# Altium schematic sheet 04_RTC_EEPROM.SchDoc(04_RTC_EEPROM)
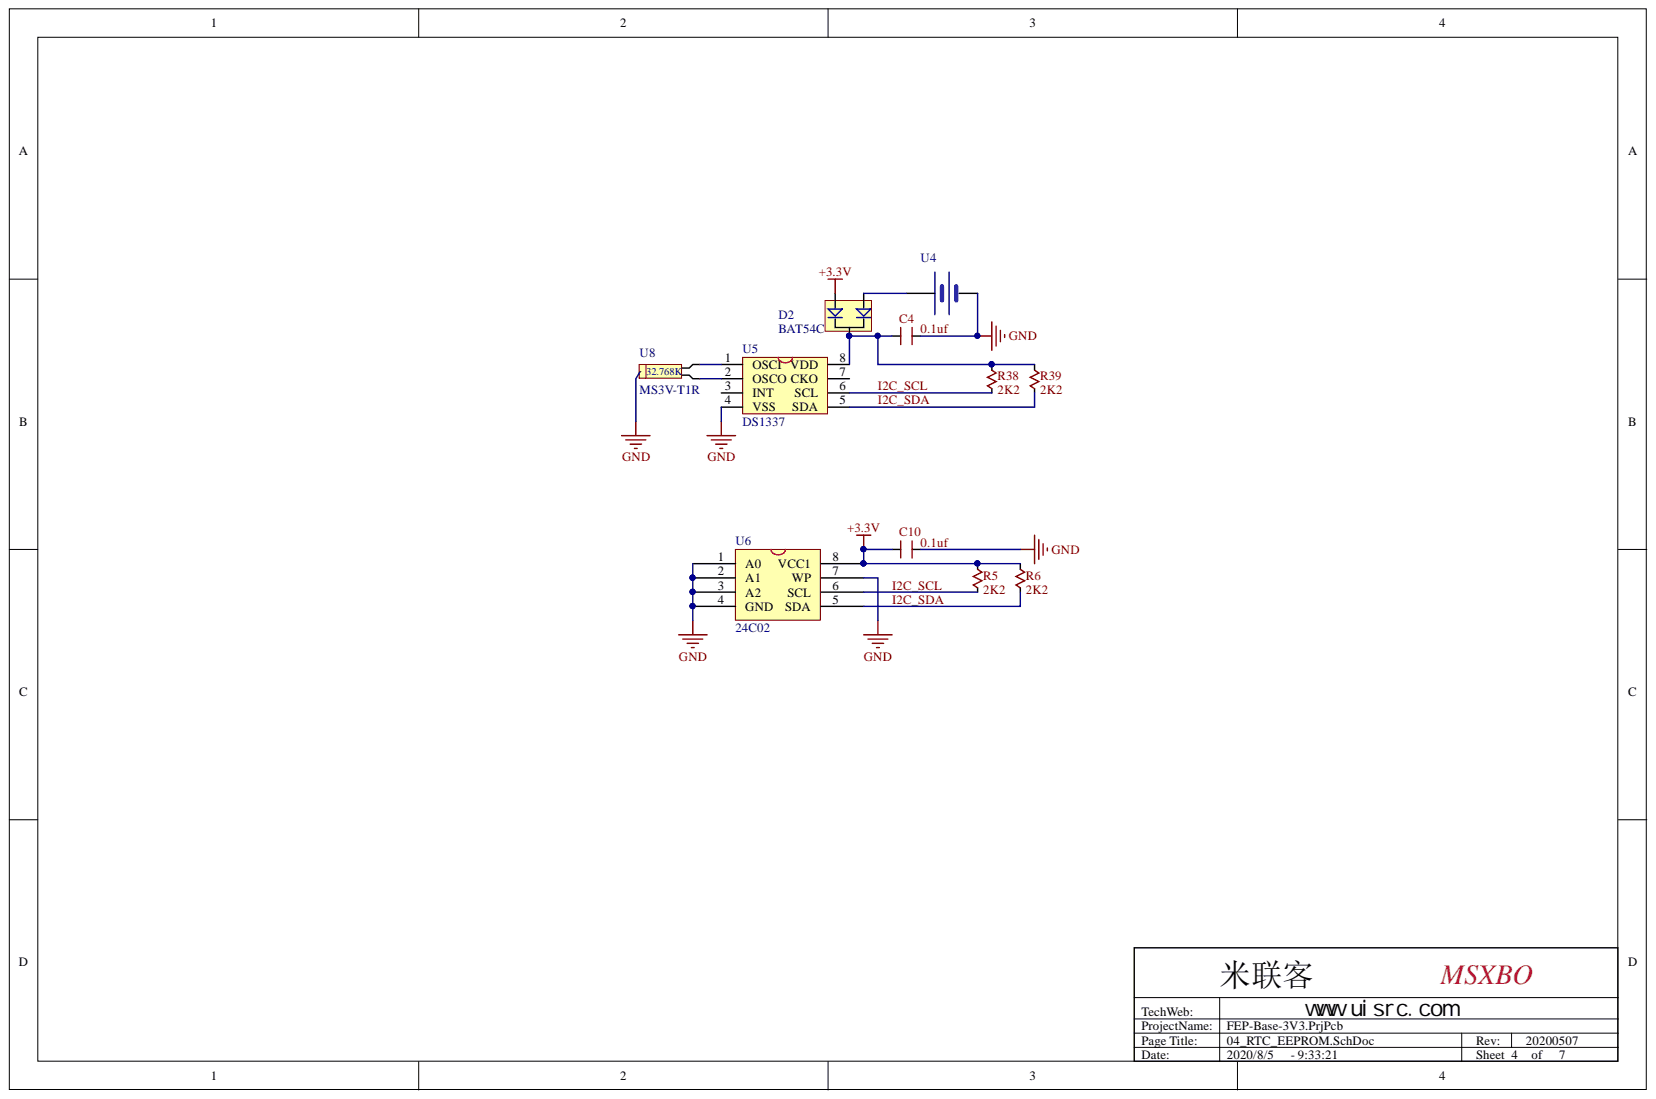
[diagram p0: 04_RTC_EEPROM.SchDoc]

## Parts
C4  0.1uf  [CAP0402]
C10  0.1uf  [CAP0402]
D2  BAT54C  [SOT-23]
R5  2K2  [RES0402]
R6  2K2  [RES0402]
R38  2K2  [RES0402]
R39  2K2  [RES0402]
U4  BAT  [BATM]  (value not drawn)
U5  DS1337  [SOP-8]
U6  24C02  [SOP-8]
U8  MS3V-T1R  [MS3V-T1R]

## Nets
+3.3V: C10.2 D2.2 R5.2 R6.2 U6.8(VCC1)
GND: C4.1 C10.1 U4.2 U5.4(VSS) U6.1(A0) U6.2(A1) U6.3(A2) U6.4(GND) U6.7(WP) U8.3
I2C_SCL: R5.1 R38.1 U5.6(SCL) U6.6(SCL)
I2C_SDA: R6.1 R39.1 U5.5(SDA) U6.5(SDA)
NetC4_2: C4.2 D2.3 R38.2 R39.2 U5.8(VDD)
NetD2_1: D2.1 U4.1
NetU5_1: U5.1(OSCI) U8.1
NetU5_2: U5.2(OSCO) U8.2

Not connected: U5 3(INT) 7(CKO)

## Library data of the parts
C4 C10 = CAPACITOR: Generic Capacitor; Component Kind: Standard; Value: 0.1uf; Library Name: Miz701_fun20160215.IntLib
D2 = BAT54C: 双肖特基二极管; Component Kind: Standard; Library Name: PIONEER.SCHLIB
R5 R6 R38 R39 = RESISTOR: Generic Resistor; Component Kind: Standard; Library Name: Digilent.IntLib; Value: 2K2
U5 = PCF8563: 时钟芯片; Component Kind: Standard; Library Name: PIONEER.SCHLIB
U6 = 24C256: Component Kind: Standard; Library Name: EliteSTM32.SCHLIB
U4 = BAT: Component Kind: Standard; Library Name: EliteSTM32.SCHLIB
U8 = MS3V-T1R: Component Kind: Standard; Library Name: MS3V-T1R.SchLib
